# Altium schematic sheet 5G_M2.SchDoc
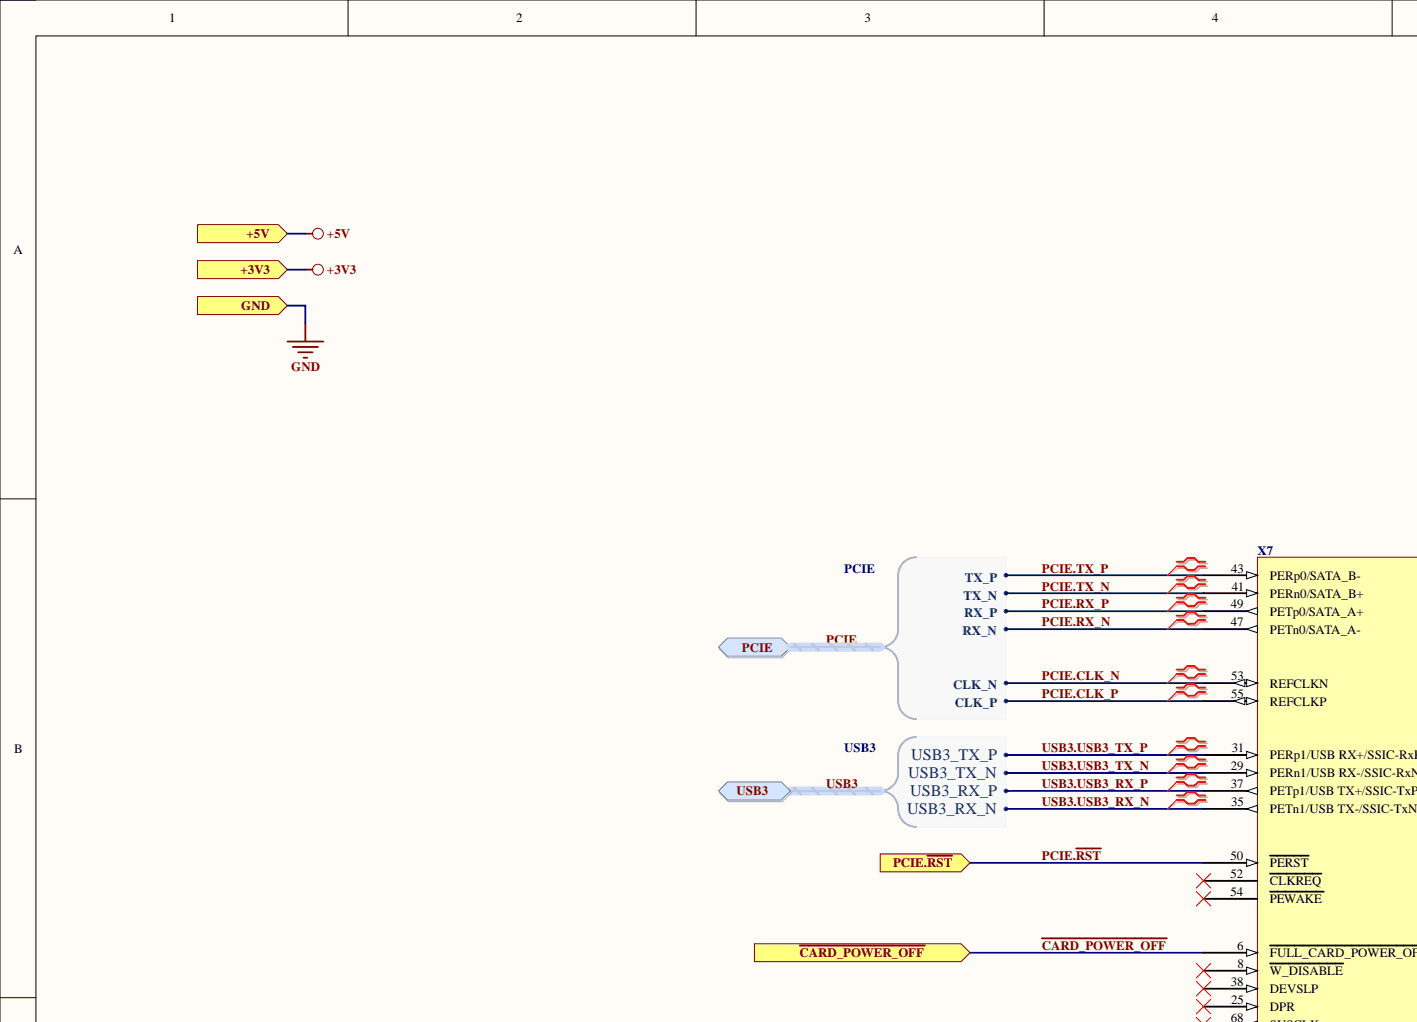
[diagram p0: 5G_M2.SchDoc, top left]
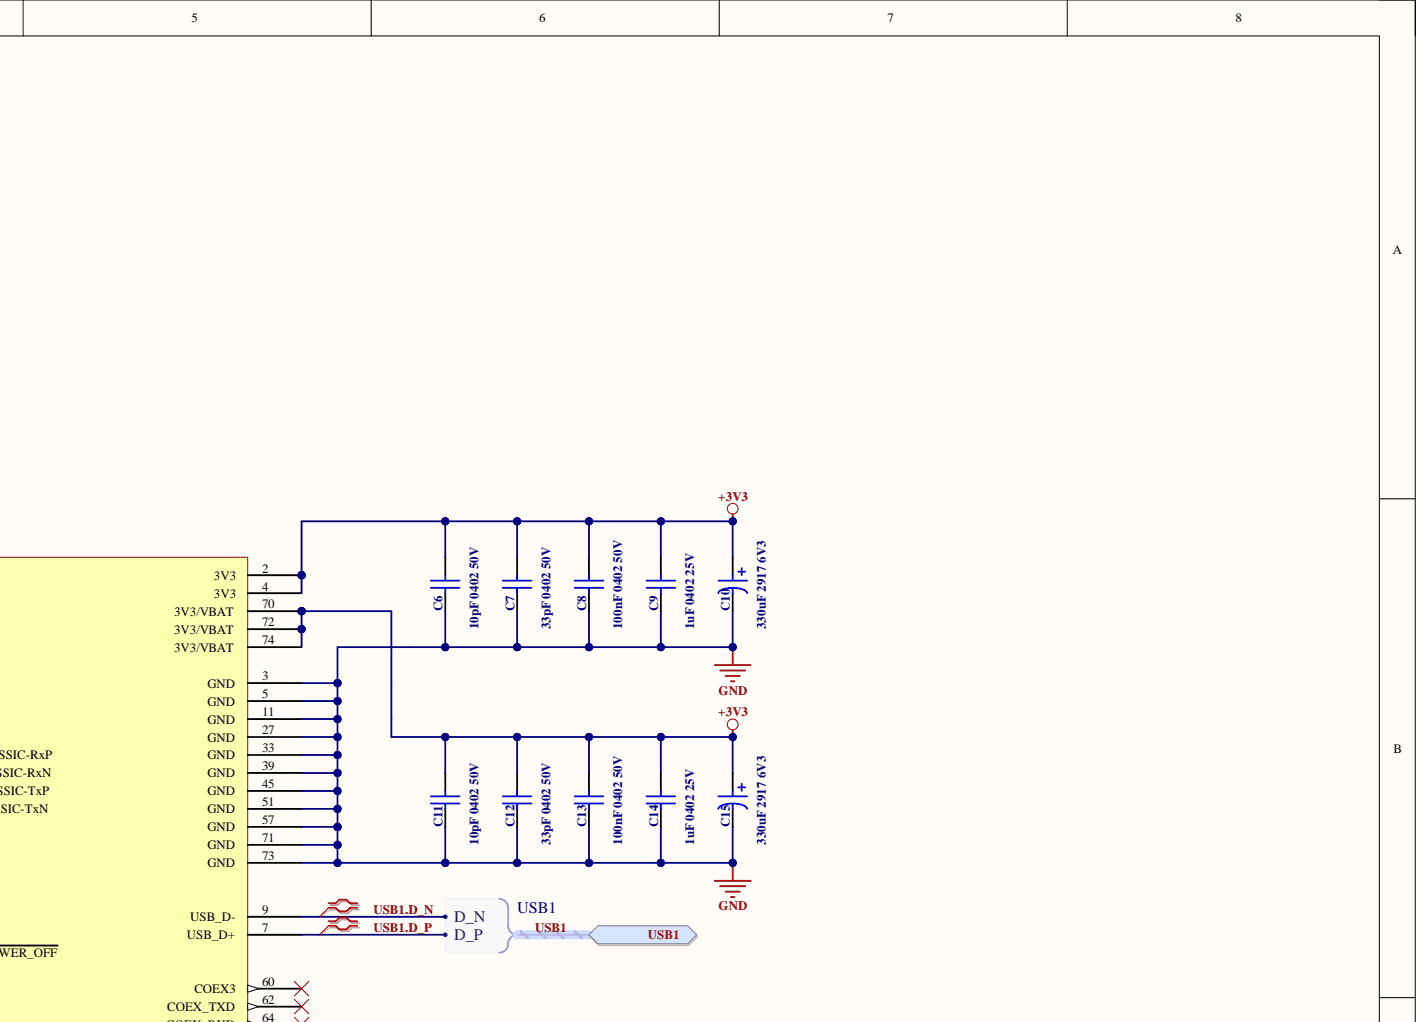
[diagram p1: 5G_M2.SchDoc, top right]
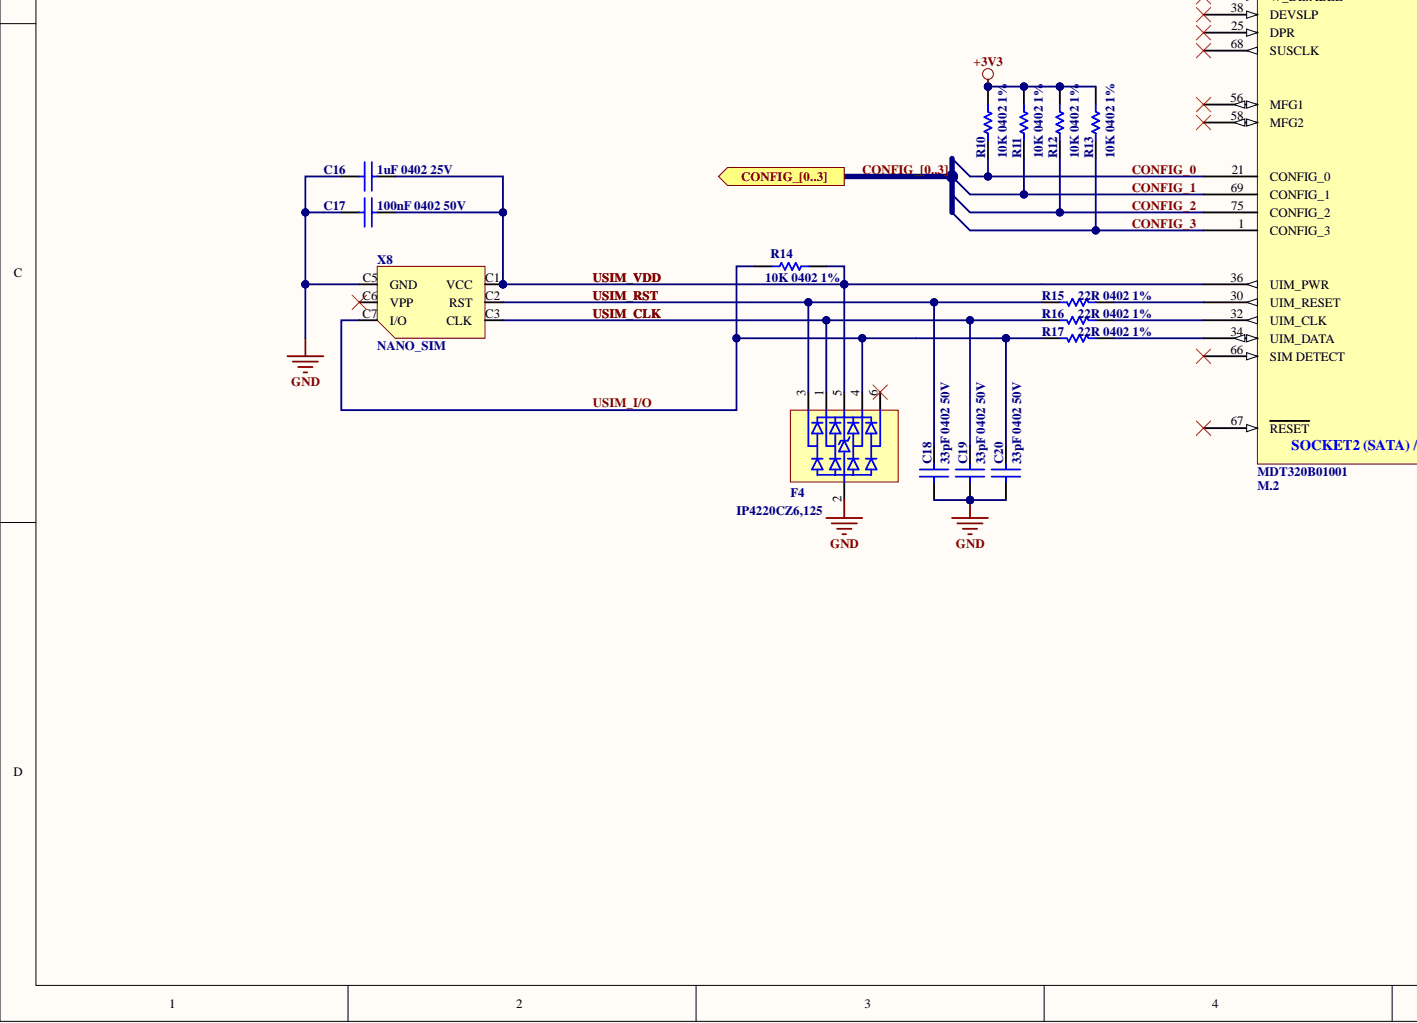
[diagram p2: 5G_M2.SchDoc, bottom left]
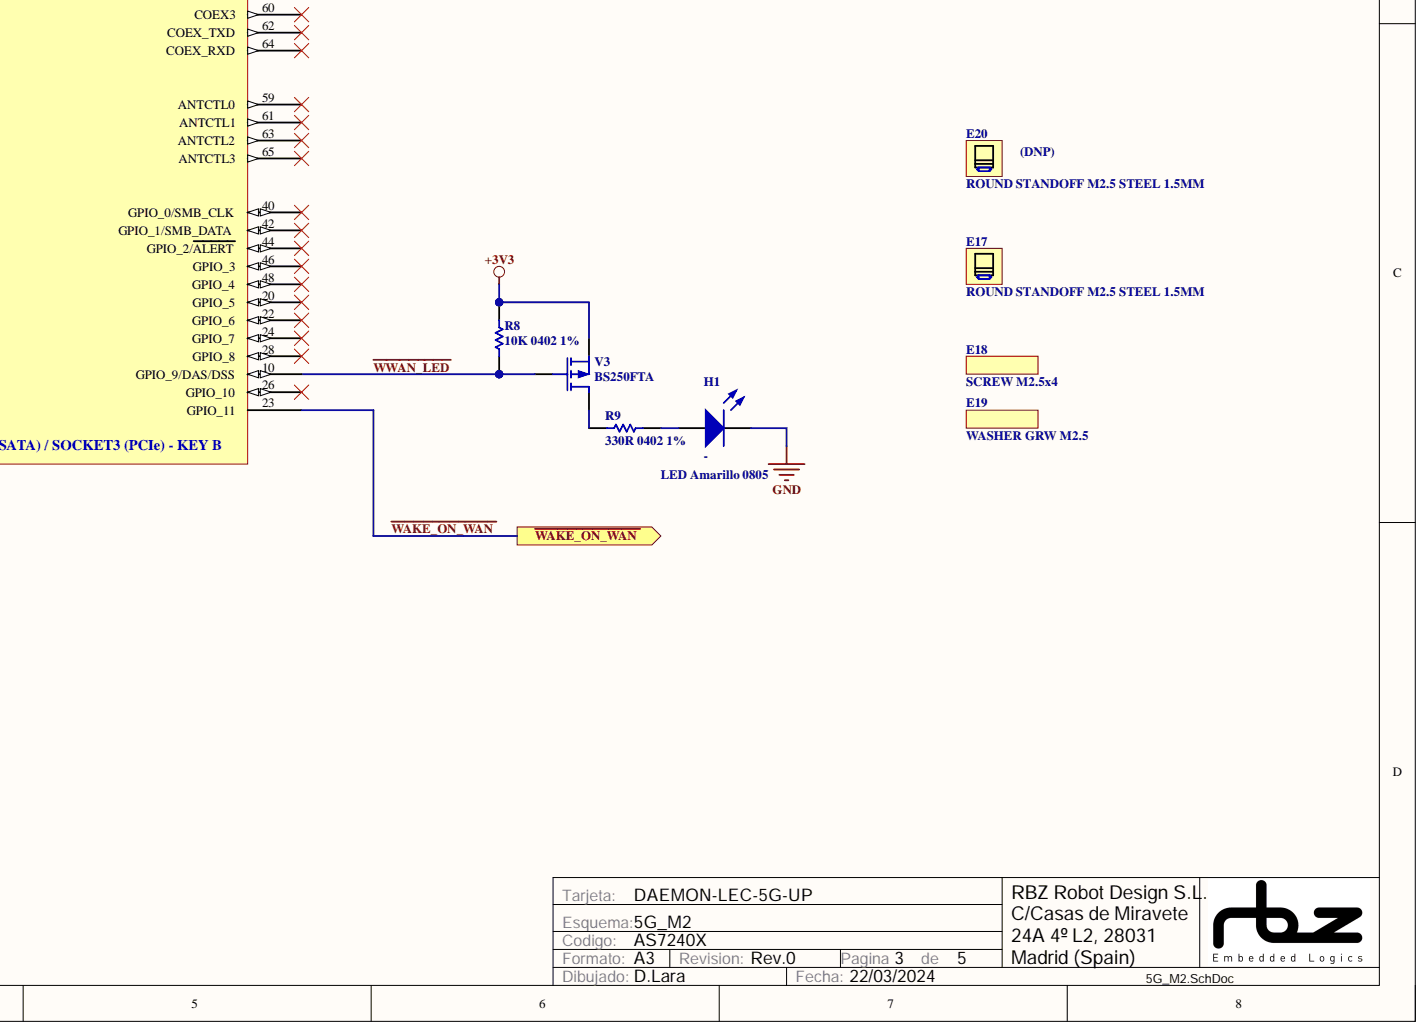
[diagram p3: 5G_M2.SchDoc, bottom right]

## Parts
C6  10pF 0402 50V  [C0402(1005)-HIGH_DENSITY]
C7  33pF 0402 50V  [C0402(1005)-HIGH_DENSITY]
C8  100nF 0402 50V  [C0402(1005)-HIGH_DENSITY]
C9  1uF 0402 25V  [C0402(1005)-HIGH_DENSITY]
C10  330uF 2917 6V3  [CAP_2917(7343)]
C11  10pF 0402 50V  [C0402(1005)-HIGH_DENSITY]
C12  33pF 0402 50V  [C0402(1005)-HIGH_DENSITY]
C13  100nF 0402 50V  [C0402(1005)-HIGH_DENSITY]
C14  1uF 0402 25V  [C0402(1005)-HIGH_DENSITY]
C15  330uF 2917 6V3  [CAP_2917(7343)]
C16  1uF 0402 25V  [C0402(1005)-HIGH_DENSITY]
C17  100nF 0402 50V  [C0402(1005)-HIGH_DENSITY]
C18  33pF 0402 50V  [C0402(1005)-HIGH_DENSITY]
C19  33pF 0402 50V  [C0402(1005)-HIGH_DENSITY]
C20  33pF 0402 50V  [C0402(1005)-HIGH_DENSITY]
E17  ROUND STANDOFF M2.5 STEEL 1.5MM  [9774015151R]
E18  SCREW M2.5x4  (mechanical)
E19  WASHER GRW M2.5  (mechanical)
E20  ROUND STANDOFF M2.5 STEEL 1.5MM  Montaje: (DNP)  [9774015151R]
F4  IP4220CZ6,125  [SOT26A-6N]
H1  -  value LED Amarillo 0805  Type: LED  [D0805(2012)]
R8  10K 0402 1%  [R0402(1005)-HIGH_DENSITY]
R9  330R 0402 1%  [R0402(1005)-HIGH_DENSITY]
R10  10K 0402 1%  [R0402(1005)-HIGH_DENSITY]
R11  10K 0402 1%  [R0402(1005)-HIGH_DENSITY]
R12  10K 0402 1%  [R0402(1005)-HIGH_DENSITY]
R13  10K 0402 1%  [R0402(1005)-HIGH_DENSITY]
R14  10K 0402 1%  [R0402(1005)-HIGH_DENSITY]
R15  22R 0402 1%  [R0402(1005)-HIGH_DENSITY]
R16  22R 0402 1%  [R0402(1005)-HIGH_DENSITY]
R17  22R 0402 1%  [R0402(1005)-HIGH_DENSITY]
V3  BS250FTA  [SOT23]
X7  MDT320B01001  Serigrafia: M.2  [FCI-MDT320B01001_HOLE_52]
X8  NANO_SIM  [SIM8051-6-0-14-01-X-revD]

## Ports
+3V3, +5V, CONFIG_0, CONFIG_1, CONFIG_2, CONFIG_3, CONFIG_[0..3], GND, PCIE, PCIE.CLK_N, PCIE.CLK_P, PCIE.RX_N, PCIE.RX_P, PCIE.TX_N, PCIE.TX_P, PCIE.~{RST}, USB1, USB1.D_N, USB1.D_P, USB3, USB3.USB3_RX_N, USB3.USB3_RX_P, USB3.USB3_TX_N, USB3.USB3_TX_P, ~{CARD_POWER_OFF}, ~{WAKE_ON_WAN}

## Nets
+3V3: C6.2 C7.2 C8.2 C9.2 C10.1 C11.2 C12.2 C13.2 C14.2 C15.1 R8.2 R10.2 R11.2 R12.2 R13.2 V3.2 X7.2(3V3) X7.4(3V3) X7.70(3V3/VBAT) X7.72(3V3/VBAT) X7.74(3V3/VBAT) ; port +3V3
~{CARD_POWER_OFF}: X7.6(FULL_CARD_POWER_OFF) ; port ~{CARD_POWER_OFF}
~{WAKE_ON_WAN}: X7.23(GPIO_11) ; port ~{WAKE_ON_WAN}
~{WWAN_LED}: R8.1 V3.1 X7.10(GPIO_9/DAS/DSS)
CONFIG_0: R10.1 X7.21(CONFIG_0) ; port CONFIG_[0..3]  [also labelled CONFIG_]
CONFIG_1: R11.1 X7.69(CONFIG_1) ; port CONFIG_[0..3]  [also labelled CONFIG_]
CONFIG_2: R12.1 X7.75(CONFIG_2) ; port CONFIG_[0..3]  [also labelled CONFIG_]
CONFIG_3: R13.1 X7.1(CONFIG_3) ; port CONFIG_[0..3]  [also labelled CONFIG_]
GND: C6.1 C7.1 C8.1 C9.1 C10.2 C11.1 C12.1 C13.1 C14.1 C15.2 C16.2 C17.2 C18.1 C19.1 C20.1 F4.2 H1.2 X7.3(GND) X7.5(GND) X7.11(GND) X7.27(GND) X7.33(GND) X7.39(GND) X7.45(GND) X7.51(GND) X7.57(GND) X7.71(GND) X7.73(GND) X8.C5(GND) ; port GND
PCIE.CLK_N: X7.53(REFCLKN) ; port PCIE
PCIE.CLK_P: X7.55(REFCLKP) ; port PCIE
PCIE.~{RST}: X7.50(PERST) ; port PCIE.~{RST}
PCIE.RX_N: X7.47(PETn0/SATA_A-) ; port PCIE
PCIE.RX_P: X7.49(PETp0/SATA_A+) ; port PCIE
PCIE.TX_N: X7.41(PERn0/SATA_B+) ; port PCIE
PCIE.TX_P: X7.43(PERp0/SATA_B-) ; port PCIE
USB1.D_N: X7.9(USB_D-) ; port USB1
USB1.D_P: X7.7(USB_D+) ; port USB1
USB3.USB3_RX_N: X7.35(PETn1/USB_TX-/SSIC-TxN) ; port USB3
USB3.USB3_RX_P: X7.37(PETp1/USB_TX+/SSIC-TxP) ; port USB3
USB3.USB3_TX_N: X7.29(PERn1/USB_RX-/SSIC-RxN) ; port USB3
USB3.USB3_TX_P: X7.31(PERp1/USB_RX+/SSIC-RxP) ; port USB3
USIM_CLK: C19.2 F4.1 R16.2 X8.C3(CLK)
USIM_I/O: C20.2 F4.4 R14.1 R17.2 X8.C7(I/O)
USIM_RST: C18.2 F4.3 R15.2 X8.C2(RST)
USIM_VDD: C16.1 C17.1 F4.5 R14.2 X7.36(UIM_PWR) X8.C1(VCC)
NetF4_6: F4.6
NetH1_1: H1.1 R9.2
NetR9_1: R9.1 V3.3
NetR15_1: R15.1 X7.30(UIM_RESET)
NetR16_1: R16.1 X7.32(UIM_CLK)
NetR17_1: R17.1 X7.34(UIM_DATA)
NetX7_8: X7.8(W_DISABLE)
NetX7_20: X7.20(GPIO_5)
NetX7_22: X7.22(GPIO_6)
NetX7_24: X7.24(GPIO_7)
NetX7_25: X7.25(DPR)
NetX7_26: X7.26(GPIO_10)
NetX7_28: X7.28(GPIO_8)
NetX7_38: X7.38(DEVSLP)
NetX7_40: X7.40(GPIO_0/SMB_CLK)
NetX7_42: X7.42(GPIO_1/SMB_DATA)
NetX7_44: X7.44(GPIO_2/ALERT)
NetX7_46: X7.46(GPIO_3)
NetX7_48: X7.48(GPIO_4)
NetX7_52: X7.52(CLKREQ)
NetX7_54: X7.54(PEWAKE)
NetX7_56: X7.56(MFG1)
NetX7_58: X7.58(MFG2)
NetX7_59: X7.59(ANTCTL0)
NetX7_60: X7.60(COEX3)
NetX7_61: X7.61(ANTCTL1)
NetX7_62: X7.62(COEX_TXD)
NetX7_63: X7.63(ANTCTL2)
NetX7_64: X7.64(COEX_RXD)
NetX7_65: X7.65(ANTCTL3)
NetX7_66: X7.66(SIM_DETECT)
NetX7_67: X7.67(RESET)
NetX7_68: X7.68(SUSCLK)
NetX8_C6: X8.C6(VPP)
+5V: ; port +5V

## Library data of the parts
C6 C11 = CC0402C0GJ050V010P: Condensador cerámico genérico 0402 ±5% NP0 -55/125°C 50V 10pF; Fabricante: TDK; Inspected: False; Precio: 0,09€; Precio Prod.: 0,007€ @1000; Ref. Catalogo: (D) 445-1235-1-ND; Referencia: C1005C0G1H100D050BA; Type: Capacitor; Codigo RBZ: CC0402C0GJ050V010P; Component Kind: Standard; Distribuidor: -; Halogen Free: -; Library Name: Capacitor_Generic_Ceramic.SchLib; Montaje: -; REACH: -; RoHS: True; Value: 10pF 0402 50V; HelpURL: S:\Condensadores\Datasheets\TDKMLCCCapRange.pdf
C7 C12 C18-C20 = CC0402C0GJ050V033P: Condensador cerámico genérico 0402 ±5% COG -55/125º 50V 33pF; Fabricante: Murata; Inspected: True; Precio: 0,08€; Precio Prod.: 0,002€ @1000; Ref. Catalogo: (D) 490-11148-1-ND; Referencia: GCM1555C1H330JA16D; Type: Capacitor; Codigo RBZ: CC0402C0GJ050V033P; Component Kind: Standard; Distribuidor: -; Halogen Free: -; Library Name: Capacitor_Generic_Ceramic.SchLib; Montaje: -; REACH: -; RoHS: True; Value: 33pF 0402 50V; HelpURL: S:\Condensadores\Datasheets\Murata_GRM155R6YA474KE01-01.pdf
C8 C13 C17 = CC0402X7RK050V100N: Condensador ceramico genérico 0402 ±10% X7R -55/125°C 50V 100nF; Fabricante: Murata; Inspected: False; Precio: 0,09€; Precio Prod.: 0,009€ @1000; Ref. Catalogo: (D) 490-10700-1-ND; Referencia: GRM155R71H104KE14D; Type: Capacitor; Codigo RBZ: CC0402X7RK050V100N; Component Kind: Standard; Distribuidor: -; Halogen Free: -; Library Name: Capacitor_Generic_Ceramic.SchLib; Montaje: -; REACH: -; RoHS: True; Value: 100nF 0402 50V; HelpURL: S:\Condensadores\Datasheets\Murata c02e.pdf
C9 C14 C16 = CC0402X5RK025V001U: Condensador ceramico genérico 0402 ±10% X5R -105/85°C 25V 1uF; Fabricante: Murata; Inspected: False; Precio: 0,09€; Precio Prod.: 0,017€ @1000; Ref. Catalogo: (D) 490-10017-1-ND; Referencia: GRM155R61E105KA12D; Type: Capacitor; Codigo RBZ: CC0402X5RK025V001U; Component Kind: Standard; Distribuidor: -; Halogen Free: -; Library Name: Capacitor_Generic_Ceramic.SchLib; Montaje: -; REACH: -; RoHS: True; Value: 1uF 0402 25V; HelpURL: S:\Condensadores\Datasheets\Murata_c02e.pdf
C10 C15 = ECG-SY0J331R: 330µF 6.3V Aluminum Polymer Capacitor  9mOhm 2000 Hrs @ 85°C 2917; Codigo RBZ: PAN_ECG-SY0J331R; Fabricante: Panasonic; Inspected: False; Precio: 1,66€; Precio Prod.: 0,684€ @1000; Ref. Catalogo: (D) P122483CT-ND; Component Kind: Standard; Distribuidor: -; Halogen Free: -; Library Name: Capacitor_Polymer-Alum.SchLib; Montaje: -; REACH: -; Referencia: ECG-SY0J331R; RoHS: True; Type: Capacitor; Value: 330uF 2917 6V3; HelpURL: S:\Condensadores\Datasheets\Panasonic_ABE0000C106.pdf
E17 E20 = 9774015151R: Fabricante: Wurth; Inspected: False; Precio: 1.09€; Ref. Catalogo: 0.83€ @100; Codigo RBZ: -; Component Kind: Standard; Description: ROUND STANDOFF M2.5 STEEL 1.5MM; Distribuidor: -; Halogen Free: -; REACH: -; Referencia: 9774015151R; RoHS: -; Type: Spacer; Value: ROUND STANDOFF M2.5 STEEL 1.5MM; HelpURL: S:\Mechanical_Parts\Datasheets\Wurth_9774015151R.pdf; Library Name: Spacers.SchLib
  E17: Montaje: -
E18 = Tornillo M2,5x4  DIN 7985: Codigo RBZ: KRA_M2.5X4/D7985B; Fabricante: Kraftberg; Inspected: False; Precio: 0,009€ @100; Precio Prod.: 0,0045€ @5000; Ref. Catalogo: (TME) M2.5X4/D7985B; Referencia: M2.5X4/D7985B; Description: Tornillo M2,5x4  DIN 7985; Distribuidor: TME; Halogen Free: -; Montaje: -; REACH: -; RoHS: -; Type: Screw; Value: SCREW M2.5x4; Library Name: Tornillos.SchLib
E19 = Arandela Grower M2,5: Codigo RBZ: TRF_DM2.5-ITST-Z100DIN6797; Fabricante: TR FASTENINGS; Inspected: False; Precio: 0,0182€; Precio Prod.: 0,0117€ @5000; Ref. Catalogo: (F) 2506060; Referencia: DM2.5-ITST-Z100DIN6797; Description: Arandela Grower M2,5; Distribuidor: -; Halogen Free: -; Montaje: -; REACH: -; RoHS: -; Type: Washer; Value: WASHER GRW M2.5; HelpURL: S:\Mechanical_Parts\Datasheets\TR_2644702.pdf; Library Name: Arandelas.SchLib
F4 = IP4220CZ6,125: USB DUAL ESD PROTECT 6TSOP; Codigo RBZ: NXP_IP4220CZ6,125; Fabricante: NXP; Inspected: False; Precio: 0,44€; Precio Prod.: 0,12€ @1000; Ref. Catalogo: (D) 568-3529-1-ND; Component Kind: Standard; Distribuidor: -; Halogen Free: -; Montaje: -; REACH: -; Referencia: IP4220CZ6,125; RoHS: True; Type: Protect; Value: USB DUAL ESD PROTECT 6TSOP; HelpURL: S:\Protecciones\datasheets\NXP IP4220CZ6_4.pdf; Library Name: Protecciones ESD.SchLib
H1 = APT2012YC: LED Yelow SMD 0805 8mcd @ 20mA; Fabricante: Kingbright; Inspected: False; Precio: 0,10€; Precio Prod.: 0,03€ @2000; Ref. Catalogo: (D) 754-1135-1-ND; Codigo RBZ: -; Component Kind: Standard; Distribuidor: -; Halogen Free: -; Montaje: -; REACH: -; Referencia: APT2012YC; RoHS: -; Serigrafia: -; Simulation: LED0; HelpURL: S:\LEDs\datasheets\Kingbright_APT2012SYC.pdf; Library Name: Color Emitting Diodes.SchLib
R8 R10-R14 = R0402F010K: Resistencia genérica 0402 1% 10K; Fabricante: Vishay; Inspected: True; Precio: 0,08€; Precio Prod.: 0,0041€ @1000; Ref. Catalogo: (D) 541-3959-1-ND; Referencia: CRCW040210K0FKEDC; Codigo RBZ: R0402F010K; Component Kind: Standard; Distribuidor: -; Halogen Free: -; Library Name: Resistor_Generic.SchLib; Montaje: -; REACH: -; RoHS: True; Type: Resistor; Value: 10K 0402 1%; HelpURL: S:\Resistencias\Datasheets\Vishay_crcwce3.pdf
R9 = R0402F330R: Resistencia genérica 0402 1% 330R; Fabricante: Panasonic; Inspected: False; Precio: 0,08€; Precio Prod.: 0,0047€ @5000; Ref. Catalogo: (D) P330LCT-ND; Referencia: ERJ-2RKF3300X; Codigo RBZ: R0402F330R; Component Kind: Standard; Distribuidor: -; Halogen Free: -; Library Name: Resistor_Generic.SchLib; Montaje: -; REACH: -; RoHS: True; Type: Resistor; Value: 330R 0402 1%; HelpURL: S:\Resistencias\Datasheets\AOA0000C304.pdf
R15-R17 = R0402F022R: Resistencia genérica 0402 1% 22R; Fabricante: Panasonic; Inspected: False; Precio: 0,08€; Precio Prod.: 0,0047€ @5000; Ref. Catalogo: (D) P22.0LCT-ND; Referencia: ERJ-2RKF22R0X; Codigo RBZ: R0402F022R; Component Kind: Standard; Distribuidor: -; Halogen Free: -; Library Name: Resistor_Generic.SchLib; Montaje: -; REACH: -; RoHS: True; Type: Resistor; Value: 22R 0402 1%; HelpURL: S:\Resistencias\Datasheets\AOA0000C304.pdf
V3 = BS250FTA: MOSFET P-CH, 90mA, 20V; Fabricante: Zetex; Inspected: False; Precio: 0.84€; Ref. Catalogo: (D) BS250FCT-ND; Codigo RBZ: -; Component Kind: Standard; Distribuidor: -; Halogen Free: -; Library Name: Transistores MOSFET.SchLib; Montaje: -; Precio Prod.: -; REACH: -; Referencia: BS250FTA; RoHS: -; Type: Transistor_MOSFET; Value: -; HelpURL: s:\transistores\datasheets\Zetex_BS250F.pdf
X7 = MDT320B01001: CONN M.2 FMALE KEY B 67POS 0.020 GOLD 3.2mm HEIGHT; Codigo RBZ: FCI_MDT320B01001; Fabricante: FCI; Inspected: False; Precio: 2,1€; Ref. Catalogo: MDT320B01001TR-ND; Component Kind: Standard; Distribuidor: -; Halogen Free: -; Library Name: FCI.SchLib; Montaje: -; REACH: -; Referencia: MDT320B01001; RoHS: True; Type: Connector; Value: CONN M.2 FMALE KEY B 67POS 0.020 GOLD 3.2mm HEIGHT; HelpURL: S:\Conectores\datasheets\mdtxxxxxx001.pdf
X8 = SIM8051-6-0-14-01-A: NANO SIM PUSH PULL, 6P, SMT, 1.3; Codigo RBZ: GCT_SIM8051-6-0-14-01-A; Fabricante: GCT; Inspected: False; Precio: 0,86€; Precio Prod.: 0,532€ @500; Ref. Catalogo: (D) 2073-SIM8051-6-0-14-01-ACT-ND; Value: CONN NANO SIM PUSH-PULL 6P; Component Kind: Standard; Distribuidor: -; Halogen Free: -; Library Name: GCT.SchLib; Montaje: -; REACH: -; Referencia: SIM8051-6-0-14-01-A; RoHS: -; Serigrafia: NANO_SIM; Type: Connector; HelpURL: S:\Conectores\datasheets\GCT_sim8051.pdf
